# Altium schematic sheet 02 LEDs.SchDoc
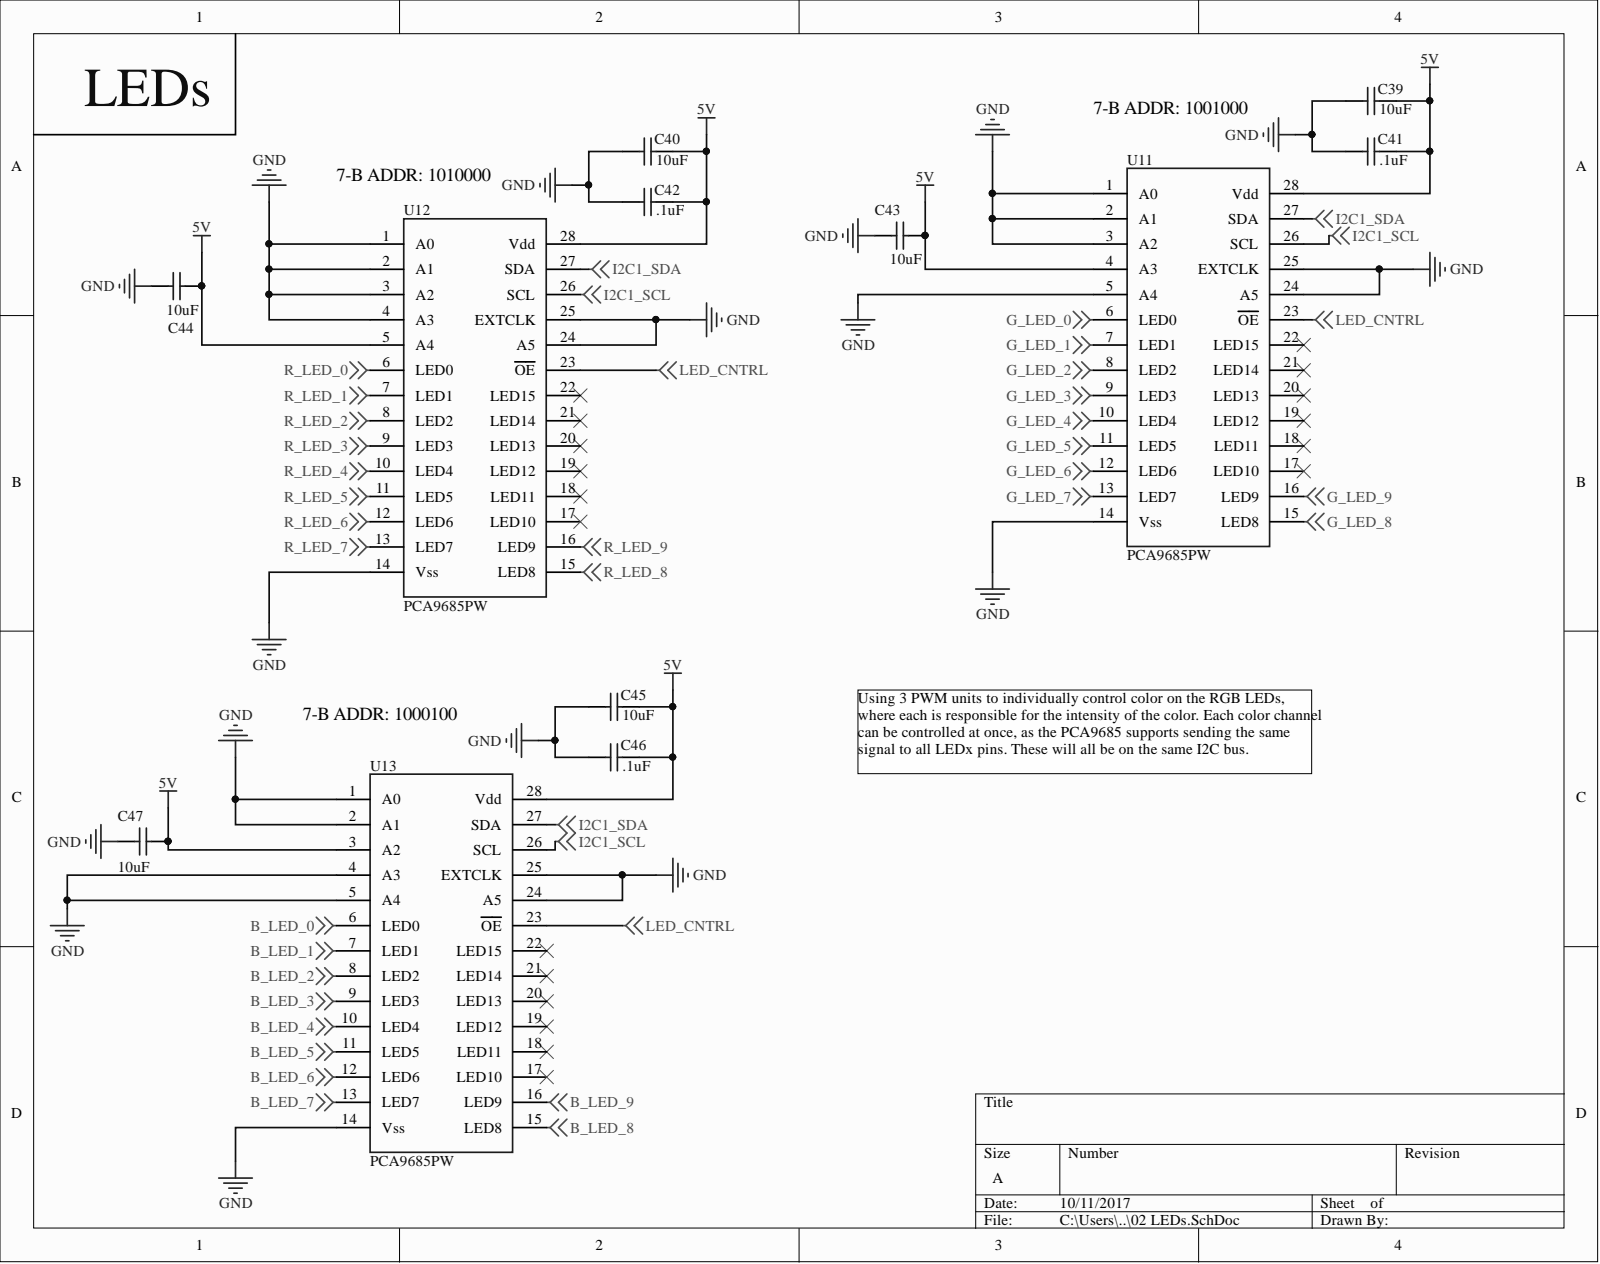
[diagram p0: 02 LEDs.SchDoc]

## Parts
C39  value 10uF  Capacitance: 10uF  [CAPC2012X135N]  (hidden comment: CAP_0805_10u_16V)
C40  value 10uF  Capacitance: 10uF  [CAPC2012X135N]  (hidden comment: CAP_0805_10u_16V)
C41  value .1uF  [CAPC2012X135N]  (hidden comment: CAP_0805_.1u_250V)
C42  value .1uF  [CAPC2012X135N]  (hidden comment: CAP_0805_.1u_250V)
C43  value 10uF  Capacitance: 10uF  [CAPC2012X135N]  (hidden comment: CAP_0805_10u_16V)
C44  value 10uF  Capacitance: 10uF  [CAPC2012X135N]  (hidden comment: CAP_0805_10u_16V)
C45  value 10uF  Capacitance: 10uF  [CAPC2012X135N]  (hidden comment: CAP_0805_10u_16V)
C46  value .1uF  [CAPC2012X135N]  (hidden comment: CAP_0805_.1u_250V)
C47  value 10uF  Capacitance: 10uF  [CAPC2012X135N]  (hidden comment: CAP_0805_10u_16V)
U11  PCA9685PW  [TSSOP28]
U12  PCA9685PW  [TSSOP28]
U13  PCA9685PW  [TSSOP28]

## Nets
5V: C39.2 C40.2 C41.2 C42.2 C43.2 C44.2 C45.2 C46.2 C47.2 U11.4(A3) U11.28(Vdd) U12.5(A4) U12.28(Vdd) U13.3(A2) U13.28(Vdd)
B_LED_0: U13.6(LED0)
B_LED_1: U13.7(LED1)
B_LED_2: U13.8(LED2)
B_LED_3: U13.9(LED3)
B_LED_4: U13.10(LED4)
B_LED_5: U13.11(LED5)
B_LED_6: U13.12(LED6)
B_LED_7: U13.13(LED7)
B_LED_8: U13.15(LED8)
B_LED_9: U13.16(LED9)
G_LED_0: U11.6(LED0)
G_LED_1: U11.7(LED1)
G_LED_2: U11.8(LED2)
G_LED_3: U11.9(LED3)
G_LED_4: U11.10(LED4)
G_LED_5: U11.11(LED5)
G_LED_6: U11.12(LED6)
G_LED_7: U11.13(LED7)
G_LED_8: U11.15(LED8)
G_LED_9: U11.16(LED9)
GND: C39.1 C40.1 C41.1 C42.1 C43.1 C44.1 C45.1 C46.1 C47.1 U11.1(A0) U11.2(A1) U11.3(A2) U11.5(A4) U11.14(Vss) U11.24(A5) U11.25(EXTCLK) U12.1(A0) U12.2(A1) U12.3(A2) U12.4(A3) U12.14(Vss) U12.24(A5) U12.25(EXTCLK) U13.1(A0) U13.2(A1) U13.4(A3) U13.5(A4) U13.14(Vss) U13.24(A5) U13.25(EXTCLK)
I2C1_SCL: U11.26(SCL) U12.26(SCL) U13.26(SCL)
I2C1_SDA: U11.27(SDA) U12.27(SDA) U13.27(SDA)
LED_CNTRL: U11.23(OE) U12.23(OE) U13.23(OE)
R_LED_0: U12.6(LED0)
R_LED_1: U12.7(LED1)
R_LED_2: U12.8(LED2)
R_LED_3: U12.9(LED3)
R_LED_4: U12.10(LED4)
R_LED_5: U12.11(LED5)
R_LED_6: U12.12(LED6)
R_LED_7: U12.13(LED7)
R_LED_8: U12.15(LED8)
R_LED_9: U12.16(LED9)

Not connected: U11 17(LED10) 18(LED11) 19(LED12) 20(LED13) 21(LED14) 22(LED15); U12 17(LED10) 18(LED11) 19(LED12) 20(LED13) 21(LED14) 22(LED15); U13 17(LED10) 18(LED11) 19(LED12) 20(LED13) 21(LED14) 22(LED15)

## Library data of the parts
C39 C40 C43-C45 C47 = CAP_0805_10u_16V: Cap Ceramic 10uF 16V X5R 10% SMD 0805 85C T/R; Manufacturer: Johanson Dielectrics; Manufacturer Part Number: 160R15X106KV4E; Case/Package: 0805; Case Code (Imperial): 0805; Case Code (Metric): 2012; Component Kind: Standard; ComponentLink1Description: https://datasheet.ciiva.com/26948/getdatasheetpartid-132904-26948555.pdf; ComponentLink1URL: https://datasheet.ciiva.com/26948/getdatasheetpartid-132904-26948555.pdf; Depth: 1.27mm; Dielectric: X5R; Height: 1.27mm; Length: 2.03mm; Max Operating Temperature: 85°C; Min Operating Temperature: -55°C; Mount: Surface Mount; Number of Pins: 2; Package Quantity: 2000; Packaging: Tape and Reel; Pricing 1: 1=0.55, 10=0.387, 100=0.2552, 500=0.189, 1000=0.1607 (USD); Radiation Hardening: No; REACH SVHC: No SVHC; Stock 1: 36636; Supplier 1: Digi-Key; Supplier Part Number 1: 709-1229-1-ND; Termination: SMD/SMT; Thickness: 0.06inch; Tolerance: 10%; Voltage Rating: 16V; Voltage Rating (DC): 16V; Weight: 0.000194oz; Width: 1.27mm; Library Name: schlib_truman.SchLib
C41 C42 C46 = CAP_0805_.1u_250V: Cap Ceramic 0.1uF 250V X7T 10% SMD 0805 125C Plastic T/R; Manufacturer: TDK; Manufacturer Part Number: C2012X7T2E104K125AE; Capacitance: 100nF; Case/Package: 0805; Case Code (Imperial): 0805; Case Code (Metric): 2012; Component Kind: Standard; ComponentLink1Description: https://datasheet.ciiva.com/24219/c2012x7t2e104k125ae-265154-24219519.pdf; ComponentLink1URL: https://datasheet.ciiva.com/24219/c2012x7t2e104k125ae-265154-24219519.pdf; Composition: Ceramic; Depth: 1.25mm; Dielectric: X7T; Height: 1.25mm; Length: 2mm; Max Operating Temperature: 125°C; Min Operating Temperature: -55°C; Package Quantity: 1; Packaging: Tape and Reel; Pricing 1: 1=0.37, 10=0.25, 100=0.1419, 500=0.1002, 1000=0.0877 (USD); REACH SVHC: No SVHC; Stock 1: 30376; Supplier 1: Digi-Key; Supplier Part Number 1: 445-8883-1-ND; Termination: SMD/SMT; Thickness: 0.049inch; Tolerance: 10%; Voltage Rating: 250V; Voltage Rating (DC): 250V; Weight: 0.000194oz; Width: 0.05inch; Library Name: schlib_truman.SchLib
U11-U13 = PCA9685PW: IC LED DRIVER RGBA 28-TSSOP; Manufacturer: NXP Semiconductors; Manufacturer Part Number: PCA9685PW,118; Frequency: 1MHz; High Level Output Current: 10mA; Low Level Output Current: 400A; Max Supply Voltage: 5.5V; Min Supply Voltage: 2.3V; Nominal Supply Current: 10A; Number of Outputs: 16; Output Current per Channel: 25mA; Power Dissipation: 400W; Case/Package: TSSOP; Component Kind: Standard; ComponentLink1Description: https://datasheet.ciiva.com/1947/pca9685-1947062.pdf; ComponentLink1URL: https://datasheet.ciiva.com/1947/pca9685-1947062.pdf; ComponentLink2Description: https://datasheet.ciiva.com/15134/getdatasheetpartid-103066-15134201.pdf; ComponentLink2URL: https://datasheet.ciiva.com/15134/getdatasheetpartid-103066-15134201.pdf; ComponentLink3Description: https://datasheet.ciiva.com/8125/pca9685-8125357.pdf; ComponentLink3URL: https://datasheet.ciiva.com/8125/pca9685-8125357.pdf; Lead Free: Lead Free; Max Operating Temperature: 85°C; Min Operating Temperature: -40°C; Mount: Surface Mount; Number of Pins: 28; Output Voltage: 5.5V; Package Quantity: 2500; Packaging: Tape and Reel; Pricing 1: 1=2.31, 10=2.074, 100=1.6674, 500=1.3699, 1000=1.1351 (USD); Radiation Hardening: No; Stock 1: 2599; Supplier 1: Digi-Key; Supplier Part Number 1: 568-11925-1-ND; Library Name: schlib_truman.SchLib
